# Altium schematic sheet P02_MPU1_JTAG.SchDoc(P02_MPU1_JTAG)
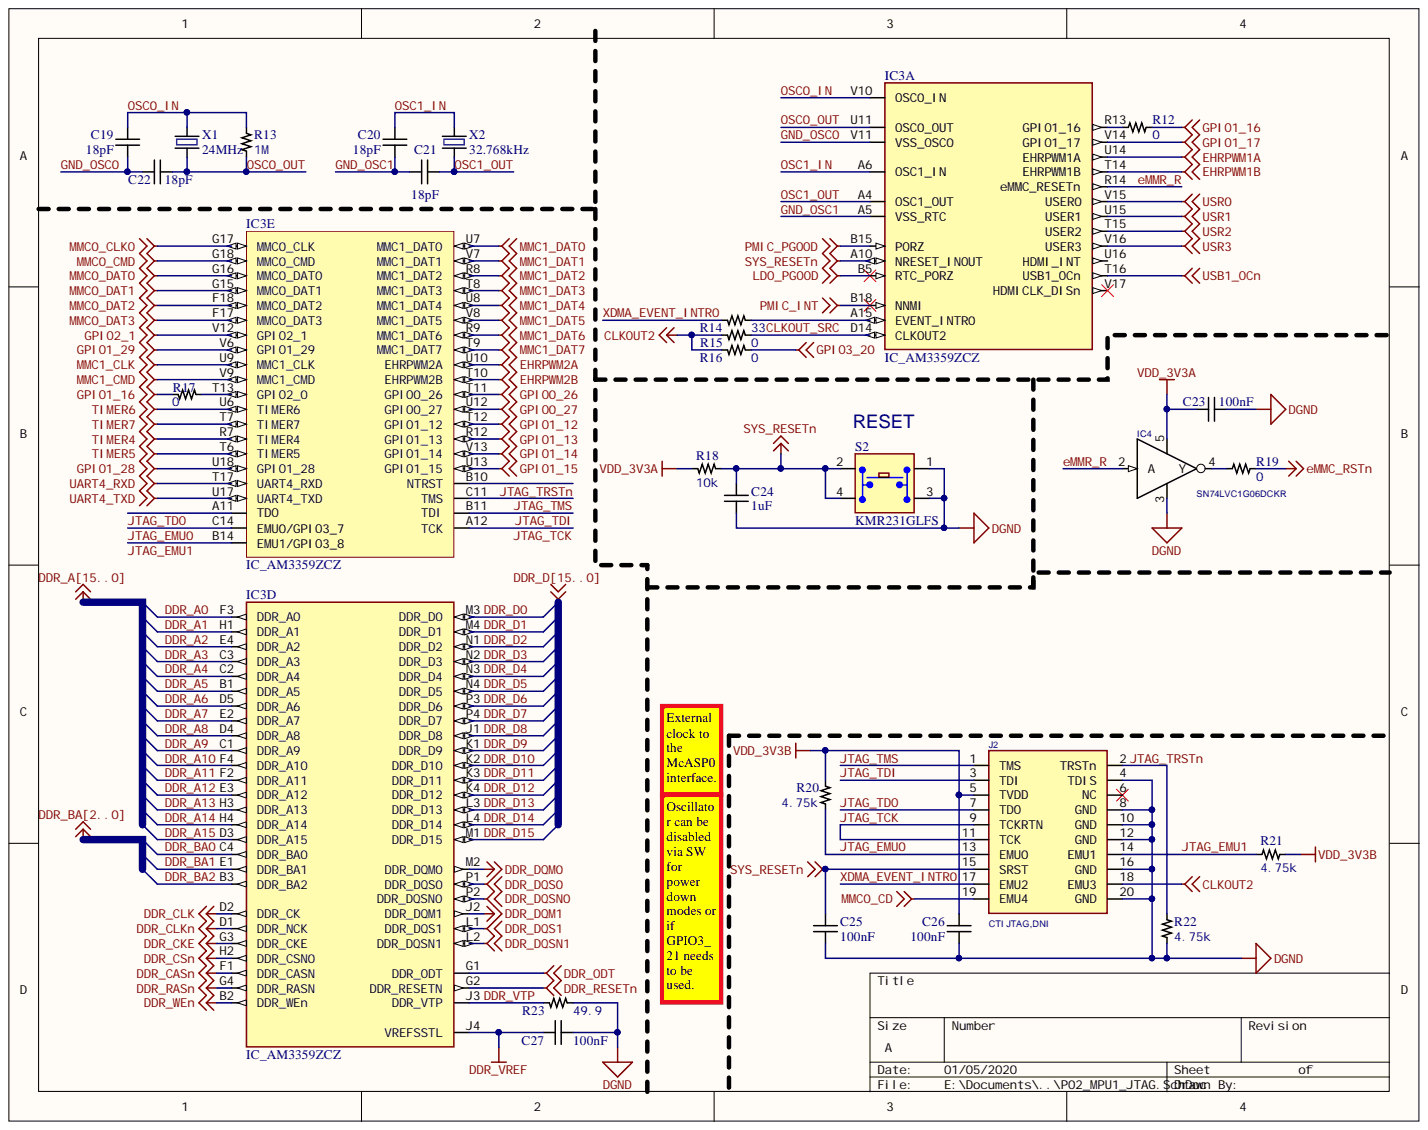
[diagram p0: P02_MPU1_JTAG.SchDoc]

## Parts
C19  Capacitance: 18pF  [CAP0402]  (hidden comment: CC0402JRNPO9BN180)
C20  Capacitance: 18pF  [CAP0402]  (hidden comment: CC0402JRNPO9BN180)
C21  Capacitance: 18pF  [CAP0402]  (hidden comment: CC0402JRNPO9BN180)
C22  Capacitance: 18pF  [CAP0402]  (hidden comment: CC0402JRNPO9BN180)
C23  Capacitance: 100nF  [CAP0402]  (hidden comment: C0402C104K4RACTU)
C24  Capacitance: 1uF  [CAP0402]  (hidden comment: CC0402KRX5R6BB105)
C25  Capacitance: 100nF  [CAP0402]  (hidden comment: C0402C104K4RACTU)
C26  Capacitance: 100nF  [CAP0402]  (hidden comment: C0402C104K4RACTU)
C27  Capacitance: 100nF  [CAP0402]  (hidden comment: C0402C104K4RACTU)
IC3  IC_AM3359ZCZ  [BGA-324]  (parts A,D,E)
IC4  SN74LVC1G06DCKR  [SC70_DCK]
J2  CTI JTAG,DNI  [HEADER10X2_SMD]
R12  RC0402JR-070RL  [RES0402]  (value not drawn)
R13  Resistance: 1M  [RES0402]  (hidden comment: RC0402FR-071ML)
R14  RC0402FR-0733RL  [RES0402]  (value not drawn)
R15  RC0402JR-070RL  [RES0402]  (value not drawn)
R16  RC0402JR-070RL  [RES0402]  (value not drawn)
R17  RC0402JR-070RL  [RES0402]  (value not drawn)
R18  Resistance: 10k  [RES0402]  (hidden comment: RC0402FR-0710KL)
R19  RC0402JR-070RL  [RES0402]  (value not drawn)
R20  Resistance: 4.75k  [RES0402]  (hidden comment: RC0402FR-074K75L)
R21  Resistance: 4.75k  [RES0402]  (hidden comment: RC0402FR-074K75L)
R22  Resistance: 4.75k  [RES0402]  (hidden comment: RC0402FR-074K75L)
R23  Resistance: 49.9  [RES0402]  (hidden comment: RC0402FR-0749R9)
S2  KMR231GLFS  [SW4SMD_63X161LS]
X1  24MHz  [XTAL150SMD_125X196]
X2  32.768kHz  [SOJ-4]

## Nets
CLKOUT2: J2.18(EMU3) R15.2 R16.2
DDR_CASn: IC3.F1(DDR_CASN)
DDR_CKE: IC3.G3(DDR_CKE)
DDR_CLK: IC3.D2(DDR_CK)
DDR_CLKn: IC3.D1(DDR_NCK)
DDR_CSn: IC3.H2(DDR_CSN0)
DDR_DQM0: IC3.M2(DDR_DQM0)
DDR_DQM1: IC3.J2(DDR_DQM1)
DDR_DQS0: IC3.P1(DDR_DQS0)
DDR_DQS1: IC3.L1(DDR_DQS1)
DDR_DQSN0: IC3.P2(DDR_DQSN0)
DDR_DQSN1: IC3.L2(DDR_DQSN1)
DDR_ODT: IC3.G1(DDR_ODT)
DDR_RASn: IC3.G4(DDR_RASN)
DDR_RESETn: IC3.G2(DDR_RESETN)
DDR_VREF: C27.1 IC3.J4(VREFSSTL)
DDR_WEn: IC3.B2(DDR_WEn)
DGND: C23.1 C24.1 C25.2 C26.2 C27.2 IC4.3 J2.4(TDIS) J2.8(GND) J2.10(GND) J2.12(GND) J2.16(GND) J2.20(GND) R22.1 R23.1 S2.1 S2.3
EHRPWM1A: IC3.U14(EHRPWM1A)
EHRPWM1B: IC3.T14(EHRPWM1B)
EHRPWM2A: IC3.U10(EHRPWM2A)
EHRPWM2B: IC3.T10(EHRPWM2B)
eMMC_RSTn: R19.2
GPIO0_26: IC3.T11(GPIO0_26)
GPIO0_27: IC3.U12(GPIO0_27)
GPIO1_12: IC3.T12(GPIO1_12)
GPIO1_13: IC3.R12(GPIO1_13)
GPIO1_14: IC3.V13(GPIO1_14)
GPIO1_15: IC3.U13(GPIO1_15)
GPIO1_16: R12.2 R17.2
GPIO1_17: IC3.V14(GPIO1_17)
GPIO1_28: IC3.U18(GPIO1_28)
GPIO1_29: IC3.V6(GPIO1_29)
GPIO2_1: IC3.V12(GPIO2_1)
GPIO3_20: R16.1
LDO_PGOOD: IC3.B5(RTC_PORZ)
MMC0_CD: J2.19(EMU4)
MMC0_CLKO: IC3.G17(MMC0_CLK)
MMC0_CMD: IC3.G18(MMC0_CMD)
MMC0_DAT0: IC3.G16(MMC0_DAT0)
MMC0_DAT1: IC3.G15(MMC0_DAT1)
MMC0_DAT2: IC3.F18(MMC0_DAT2)
MMC0_DAT3: IC3.F17(MMC0_DAT3)
MMC1_CLK: IC3.U9(MMC1_CLK)
MMC1_CMD: IC3.V9(MMC1_CMD)
MMC1_DAT0: IC3.U7(MMC1_DAT0)
MMC1_DAT1: IC3.V7(MMC1_DAT1)
MMC1_DAT2: IC3.R8(MMC1_DAT2)
MMC1_DAT3: IC3.T8(MMC1_DAT3)
MMC1_DAT4: IC3.U8(MMC1_DAT4)
MMC1_DAT5: IC3.V8(MMC1_DAT5)
MMC1_DAT6: IC3.R9(MMC1_DAT6)
MMC1_DAT7: IC3.T9(MMC1_DAT7)
PMIC_INT: IC3.B18(NNMI)
PMIC_PGOOD: IC3.B15(PORZ)
SYS_RESETn: C24.2 C25.1 IC3.A10(NRESET_INOUT) J2.15(SRST) R18.2 S2.2 S2.4
TIMER4: IC3.R7(TIMER4)
TIMER5: IC3.T6(TIMER5)
TIMER6: IC3.U6(TIMER6)
TIMER7: IC3.T7(TIMER7)
UART4_RXD: IC3.T17(UART4_RXD)
UART4_TXD: IC3.U17(UART4_TXD)
USB1_OCn: IC3.T16(USB1_OCn)
USR0: IC3.V15(USER0)
USR1: IC3.U15(USER1)
USR2: IC3.T15(USER2)
USR3: IC3.V16(USER3)
VDD_3V3A: C23.2 IC4.5 R18.1
VDD_3V3B: C26.1 J2.5(TVDD) R20.2 R21.1
CLKOUT_SRC: IC3.D14(CLKOUT2) R15.1
DDR_A0: IC3.F3(DDR_A0)  [also labelled DDR_A]
DDR_A1: IC3.H1(DDR_A1)  [also labelled DDR_A]
DDR_A2: IC3.E4(DDR_A2)  [also labelled DDR_A]
DDR_A3: IC3.C3(DDR_A3)  [also labelled DDR_A]
DDR_A4: IC3.C2(DDR_A4)  [also labelled DDR_A]
DDR_A5: IC3.B1(DDR_A5)  [also labelled DDR_A]
DDR_A6: IC3.D5(DDR_A6)  [also labelled DDR_A]
DDR_A7: IC3.E2(DDR_A7)  [also labelled DDR_A]
DDR_A8: IC3.D4(DDR_A8)  [also labelled DDR_A]
DDR_A9: IC3.C1(DDR_A9)  [also labelled DDR_A]
DDR_A10: IC3.F4(DDR_A10)  [also labelled DDR_A]
DDR_A11: IC3.F2(DDR_A11)  [also labelled DDR_A]
DDR_A12: IC3.E3(DDR_A12)  [also labelled DDR_A]
DDR_A13: IC3.H3(DDR_A13)  [also labelled DDR_A]
DDR_A14: IC3.H4(DDR_A14)  [also labelled DDR_A]
DDR_A15: IC3.D3(DDR_A15)  [also labelled DDR_A]
DDR_BA0: IC3.C4(DDR_BA0)  [also labelled DDR_BA]
DDR_BA1: IC3.E1(DDR_BA1)  [also labelled DDR_BA]
DDR_BA2: IC3.B3(DDR_BA2)  [also labelled DDR_BA]
DDR_D0: IC3.M3(DDR_D0)  [also labelled DDR_D]
DDR_D1: IC3.M4(DDR_D1)  [also labelled DDR_D]
DDR_D2: IC3.N1(DDR_D2)  [also labelled DDR_D]
DDR_D3: IC3.N2(DDR_D3)  [also labelled DDR_D]
DDR_D4: IC3.N3(DDR_D4)  [also labelled DDR_D]
DDR_D5: IC3.N4(DDR_D5)  [also labelled DDR_D]
DDR_D6: IC3.P3(DDR_D6)  [also labelled DDR_D]
DDR_D7: IC3.P4(DDR_D7)  [also labelled DDR_D]
DDR_D8: IC3.J1(DDR_D8)  [also labelled DDR_D]
DDR_D9: IC3.K1(DDR_D9)  [also labelled DDR_D]
DDR_D10: IC3.K2(DDR_D10)  [also labelled DDR_D]
DDR_D11: IC3.K3(DDR_D11)  [also labelled DDR_D]
DDR_D12: IC3.K4(DDR_D12)  [also labelled DDR_D]
DDR_D13: IC3.L3(DDR_D13)  [also labelled DDR_D]
DDR_D14: IC3.L4(DDR_D14)  [also labelled DDR_D]
DDR_D15: IC3.M1(DDR_D15)  [also labelled DDR_D]
DDR_VTP: IC3.J3(DDR_VTP) R23.2
eMMR_R: IC3.R14(eMMC_RESETn) IC4.2(A)
GND_OSC0: C19.2 C22.1 IC3.V11(VSS_OSC0)
GND_OSC1: C20.1 C21.2 IC3.A5(VSS_RTC)
JTAG_EMU0: IC3.C14(EMU0/GPIO3_7) J2.13(EMU0) R20.1
JTAG_EMU1: IC3.B14(EMU1/GPIO3_8) J2.14(EMU1) R21.2
JTAG_TCK: IC3.A12(TCK) J2.9(TCKRTN) J2.11(TCK)
JTAG_TDI: IC3.B11(TDI) J2.3(TDI)
JTAG_TDO: IC3.A11(TDO) J2.7(TDO)
JTAG_TMS: IC3.C11(TMS) J2.1(TMS)
JTAG_TRSTn: IC3.B10(NTRST) J2.2(TRSTn) R22.2
OSC0_IN: C19.1 IC3.V10(OSC0_IN) R13.2 X1.2
OSC0_OUT: C22.2 IC3.U11(OSC0_OUT) R13.1 X1.1
OSC1_IN: C20.2 IC3.A6(OSC1_IN) X2.1
OSC1_OUT: C21.1 IC3.A4(OSC1_OUT) X2.4
XDMA_EVENT_INTR0: J2.17(EMU2) R14.1
NetIC3_A15: IC3.A15(EVENT_INTR0) R14.2
NetIC3_R13: IC3.R13(GPIO1_16) R12.1
NetIC3_T13: IC3.T13(GPIO2_0) R17.1
NetIC4_4: IC4.4(Y) R19.1

Not connected: IC3 U16(HDMI_INT) V17(HDMICLK_DISn); J2 6(NC)

## Library data of the parts
C19-C22 = CAP_18pF: MLCC 18pF 0402 SMD; Manufacturer: Yageo; Voltage Rating (DC): 50V; Tolerance: 5%; Temperature Coefficient: 30ppm/°C; Dielectric: C0G; Width: 0.02inch; Weight: 2.3E-05oz; Voltage Rating: 50V; Voltage: 50V; Thickness: 0.022inch; Termination: SMD/SMT; Supplier 1: Digi-Key; Stock 1: 740828; RoHS: Yes; REACH SVHC: No SVHC; Radiation Hardening: No; Pricing 1: 1=0.10000, 10=0.02300, 100=0.01030, 500=0.00736, 1000=0.00578, 2500=0.00525, 5000=0.00483 (USD); Packaging: Tape and Reel; Package Quantity: 1; Number of Pins: 2; Mount: Surface Mount; Min Operating Temperature: -55°C; Max Operating Temperature: 125°C; Manufacturer Part Number: CC0402JRNPO9BN180; Length: 1mm; Lead Free: Lead Free; Height: 0.5mm; Depth: 0.5mm; Composition: Ceramic; ComponentLink7URL: https://datasheet.ciiva.com/11587/upy-gp-np0-16v-to-50v-8-11587087.pdf?src-supplier=Avnet; ComponentLink7Description: https://datasheet.ciiva.com/11587/upy-gp-np0-16v-to-50v-8-11587087.pdf?src-supplier=Avnet; ComponentLink6URL: https://datasheet.ciiva.com/13208/upy-gp-np0-16v-to-50v-8-13208430.pdf?src-supplier=Mouser; ComponentLink6Description: https://datasheet.ciiva.com/13208/upy-gp-np0-16v-to-50v-8-13208430.pdf?src-supplier=Mouser; ComponentLink5URL: https://datasheet.ciiva.com/28450/1443161-28450232.pdf?src-supplier=Element14; ComponentLink5Description: https://datasheet.ciiva.com/28450/1443161-28450232.pdf?src-supplier=Element14; ComponentLink4URL: https://datasheet.ciiva.com/176/mlccorderingcode-176359.pdf?src-supplier=Digi-Key; ComponentLink4Description: https://datasheet.ciiva.com/176/mlccorderingcode-176359.pdf?src-supplier=Digi-Key; ComponentLink3URL: https://datasheet.ciiva.com/176/mlccorderingcode-176358.pdf?src-supplier=Digi-Key; ComponentLink3Description: https://datasheet.ciiva.com/176/mlccorderingcode-176358.pdf?src-supplier=Digi-Key; ComponentLink2URL: https://datasheet.ciiva.com/176/mlccorderingcode-176357.pdf?src-supplier=Digi-Key; ComponentLink2Description: https://datasheet.ciiva.com/176/mlccorderingcode-176357.pdf?src-supplier=Digi-Key; ComponentLink1URL: https://datasheet.ciiva.com/11788/0900766b80d84fbf-11788369.pdf?src-supplier=RSComponents; ComponentLink1Description: https://datasheet.ciiva.com/11788/0900766b80d84fbf-11788369.pdf?src-supplier=RSComponents; Component Kind: Standard; Case Code (Metric): 1005; Case Code (Imperial): 0402; Case/Package: 0402; Library Name: Orient.SchLib
C23 C25-C27 = CAP_100nF: MLCC 100nF 0402 SMD; Manufacturer: KEMET; Voltage Rating (DC): 16V; Tolerance: 10%; Dissipation Factor: 3.5%; Dielectric: X7R; Width: 0.5mm; Voltage Rating: 16V; Termination: SMD/SMT; Supplier Part Number 1: 399-3521-1-ND; Supplier 1: Digi-Key; Stock 1: 6512243; RoHS: Yes; Radiation Hardening: No; Pricing 1: 1=0.10000, 10=0.04200, 100=0.01860, 500=0.01328, 1000=0.01044, 2500=0.00949, 5000=0.00873 (USD); Packaging: Tape and Reel; Package Quantity: 10000; Mount: Surface Mount; Min Operating Temperature: -55°C; Max Operating Temperature: 125°C; Manufacturer Part Number: C0402C104K4RACTU; Length: 1mm; Height: 0.5mm; Depth: 0.5mm; Contact Plating: Tin,Matte; ComponentLink2URL: https://datasheet.ciiva.com/18192/getdatasheetpartid-385384-18192412.pdf?src-supplier=Verical; ComponentLink2Description: Datasheet; Component Kind: Standard; Case Code (Metric): 1005; Case Code (Imperial): 0402; Case/Package: 0402; Library Name: Orient.SchLib
C24 = CAP_1uF: MLCC 1uF 0402 SMD; Manufacturer: Yageo; Voltage Rating (DC): 10V; Tolerance: 10%; Dissipation Factor: 15%; Dielectric: X5R; Width: 0.02inch; Voltage Rating: 10V; Thickness: 0.022inch; Termination: SMD/SMT; Supplier 1: Digi-Key; Stock 1: 6411796; RoHS: Yes; REACH SVHC: No SVHC; Radiation Hardening: No; Pricing 1: 1=0.10000, 10=0.06300, 100=0.02790, 500=0.01996, 1000=0.01568, 2500=0.01425, 5000=0.01311 (USD); Packaging: Tape and Reel; Package Quantity: 1; Number of Pins: 2; Mount: Surface Mount; Min Operating Temperature: -55°C; Max Operating Temperature: 85°C; Manufacturer Part Number: CC0402KRX5R6BB105; Length: 1mm; Lead Free: Lead Free; Height: 0.5mm; Halogen Free: Halogen Free; Depth: 0.5mm; Composition: Ceramic; ComponentLink3URL: https://datasheet.ciiva.com/176/mlccorderingcode-176705.pdf?src-supplier=Digi-Key; ComponentLink3Description: https://datasheet.ciiva.com/176/mlccorderingcode-176705.pdf?src-supplier=Digi-Key; ComponentLink2URL: https://datasheet.ciiva.com/176/mlccorderingcode-176706.pdf?src-supplier=Digi-Key; ComponentLink2Description: https://datasheet.ciiva.com/176/mlccorderingcode-176706.pdf?src-supplier=Digi-Key; ComponentLink1URL: https://datasheet.ciiva.com/176/upy-gphc-x5r-4v-to-50v-12-176704.pdf?src-supplier=Digi-Key; ComponentLink1Description: https://datasheet.ciiva.com/176/upy-gphc-x5r-4v-to-50v-12-176704.pdf?src-supplier=Digi-Key; Component Kind: Standard; Case Code (Metric): 1005; Case Code (Imperial): 0402; Case/Package: 0402; Library Name: Orient.SchLib
IC3 = IC_AM335X_ZCE_1: SubArctic Cortex A8 Processor 18x18; Manufacturer Part Number: XAM3359ZCZ; Manufacturer: Texas Instruments; Voltage: 1.06 V ~ 1.15 V; Processor Type: ARM Microprocessor; Supplier Part Number 1: 296-35073-ND; Supplier Device Package: 324-NFBGA(15x15); Supplier 1: Digi-Key; Stock 1: 16; Speed: -; RoHS: RoHS Compliant; Pricing 1: 1=33.5, 10=30.988, 100=26.465, 250=24.28752, 500=23.115, 1000=21.775, 2500=21.105, 5000=20.6025, 10000=20.1 (USD); Packaging: Tray; Package / Case: 324-LFBGA; Mounting Type: Surface Mount; Features: -; ComponentLink1URL: http://www.ti.com/lit/ds/symlink/am3354.pdf; ComponentLink1Description: http://www.ti.com/lit/ds/symlink/am3354.pdf; Component Kind: Standard; Category: Integrated Circuits (ICs); Library Name: Orient.SchLib
IC4 = IC_Inverter: IC INVERTER SINGLE 1INPUT SC705; Manufacturer Part Number: SN74LVC1G06DCKTG4; Manufacturer: Texas Instruments; Voltage - Supply: 1.65 V ~ 5.5 V; Turn-On Delay Time: 3ns; Quiescent Current: 10uA; Propagation Delay: 6.5ns; Polarity: Inverting; Output Current: 32mA; Number of Outputs: 1; Min Supply Voltage: 1.65V; Max Supply Voltage: 5.5V; Max Propagation Delay @ V, Max CL: 3ns @ 5V, 50pF; Logic Type: Inverter, Buffer; Logic Level - Low: 0.7 V ~ 0.8 V; Logic Level - High: 1.7 V ~ 2 V; Logic Function: Buffer,Inverter; Current - Quiescent (Max): 10µA; Current - Output High, Low: -, 32mA; Width: 1.25mm; Supplier Part Number 1: SN74LVC1G06DCKTG4-ND; Supplier Device Package: SC-70-5; Supplier 2: Digi-Key; Supplier 1: Digi-Key; Stock 2: 23745; Stock 1: 0; RoHS: RoHS Compliant; REACH SVHC: No SVHC; Radiation Hardening: No; Pricing 2: 1=0.33000, 10=0.24700, 100=0.14000, 500=0.09270, 1000=0.07107 (USD); Pricing 1: 1250=0.32 (USD); Packaging: Tape & Reel (TR); Package Quantity: 3000; Package / Case: 6-TSSOP (5 Lead), SC-88A, SOT-353; Operating Temperature: -40°C ~ 85°C; Number of Pins: 5; Number of Output Lines: 1; Number of Inputs: 1; Number of Input Lines: 1; Number of Gates: 1; Number of Elements: 1; Number of Circuits: 1; Number of Channels: 1; Number of Bits: 1; Mounting Type: Surface Mount; Mount: Surface Mount; Min Operating Temperature: -40°C; Max Operating Temperature: 125°C; Low Level Output Current: 32mA; Length: 2mm; Lead Free: Lead Free; High Level Output Current: 32mA; Height: 0.9mm; Features: Open Drain; Contact Plating: Gold; ComponentLink10URL: https://datasheet.ciiva.com/26220/sn74lvc1g06-26220911.pdf?src-supplier=Avnet+Europe; ComponentLink10Description: https://datasheet.ciiva.com/26220/sn74lvc1g06-26220911.pdf?src-supplier=Avnet+Europe; ComponentLink9URL: https://datasheet.ciiva.com/46442/1857056-46442057.pdf?src-supplier=Element14; ComponentLink9Description: https://datasheet.ciiva.com/46442/1857056-46442057.pdf?src-supplier=Element14; ComponentLink8URL: https://datasheet.ciiva.com/7783/1633749-7783590.pdf?src-supplier=Element14; ComponentLink8Description: https://datasheet.ciiva.com/7783/1633749-7783590.pdf?src-supplier=Element14; ComponentLink7URL: https://datasheet.ciiva.com/16531/sn74lvc1g06-16531989.pdf?src-supplier=Avnet+Asia; ComponentLink7Description: https://datasheet.ciiva.com/16531/sn74lvc1g06-16531989.pdf?src-supplier=Avnet+Asia; ComponentLink6URL: https://datasheet.ciiva.com/1864/sn74lvc1g06-1864403.pdf?src-supplier=Digi-Key; ComponentLink6Description: https://datasheet.ciiva.com/1864/sn74lvc1g06-1864403.pdf?src-supplier=Digi-Key; ComponentLink5URL: https://datasheet.ciiva.com/1864/sn74lvc1g06-1864402.pdf?src-supplier=Digi-Key; ComponentLink5Description: https://datasheet.ciiva.com/1864/sn74lvc1g06-1864402.pdf?src-supplier=Digi-Key; ComponentLink4URL: https://datasheet.ciiva.com/1864/sn74lvc1g06-1864401.pdf?src-supplier=Digi-Key; ComponentLink4Description: https://datasheet.ciiva.com/1864/sn74lvc1g06-1864401.pdf?src-supplier=Digi-Key; ComponentLink3URL: https://datasheet.ciiva.com/34301/1043820-34301914.pdf?src-supplier=Jameco; ComponentLink3Description: https://datasheet.ciiva.com/34301/1043820-34301914.pdf?src-supplier=Jameco; ComponentLink2URL: https://datasheet.ciiva.com/15094/getdatasheetpartid-76668-15094547.pdf?src-supplier=Verical; ComponentLink2Description: https://datasheet.ciiva.com/15094/getdatasheetpartid-76668-15094547.pdf?src-supplier=Verical; ComponentLink1URL: http://www.ti.com/lit/ds/symlink/sn74lvc1g06.pdf; ComponentLink1Description: http://www.ti.com/lit/ds/symlink/sn74lvc1g06.pdf; Component Kind: Standard; Category: Integrated Circuits (ICs); Case/Package: SC; Library Name: Orient.SchLib
J2 = HDR_10x2_CJTAG: Dual Line Header 10x2pin Tin; Manufacturer Part Number: FTR-110-03-G-D-06; Manufacturer: Samtec Inc; Row Spacing: 0.100" (2.54mm); Pitch: 0.050" (1.27mm); Number of Positions Loaded: 19; Number of Positions: 20; Connector Type: Unshrouded; Termination: Solder; Supplier Part Number 1: FTR-110-03-G-D-06-ND; Supplier 1: Digi-Key; Stock 1: 0; RoHS: RoHS Compliant; Pricing 1: 1=4.47 (USD); Packaging: Tube; Number of Rows: 2; Mounting Type: Surface Mount; Molding Height Above Board: 0.100" (2.54mm); Height Stacking (Mating): -; Features: -; Contact Mating Length: 0.125" (3.18mm); Contact Finish Thickness: 10µin (0.25µm); Contact Finish: Gold; ComponentLink1URL: http://www.samtec.com/documents/webfiles/cpdf/FTR-1XX-XX-XX-D-X-XX-MKT.pdf; ComponentLink1Description: http://www.samtec.com/documents/webfiles/cpdf/FTR-1XX-XX-XX-D-X-XX-MKT.pdf; Component Kind: Standard; Color: Black; Category: Connectors, Interconnects; Library Name: Orient.SchLib
R12 R15-R17 R19 = RES_0: Resistor 0Ohm 0402 SMD; Manufacturer: Yageo; Voltage Rating: 50V; Tolerance: 5%; Temperature Coefficient: 200ppm/°C; Resistance: 0; Power Rating: 62.5mW; Max Power Dissipation: 63mW; Width: 0.02inch; Termination: SMD/SMT; Supplier Part Number 1: 311-0.0JRCT-ND; Supplier 1: Digi-Key; Stock 1: 68160806; RoHS: Yes; REACH SVHC: Unknown; Radiation Hardening: No; Pricing 1: 1=0.10000, 10=0.01300, 25=0.00920, 50=0.00700, 100=0.00510, 250=0.00392, 500=0.00312, 1000=0.00230, 5000=0.00165 (USD); Packaging: Tape and Reel; Package Quantity: 1; Number of Terminations: 2; Min Operating Temperature: -55°C; Military Standard: Not; Max Operating Temperature: 155°C; Manufacturer Part Number: RC0402JR-070RL; Length: 1mm; Lead Free: Lead Free; Height: 0.016inch; Features: Moisture Resistant; Depth: 0.5mm; Contact Plating: Tin,Matte; Composition: Thick Film; ComponentLink2URL: https://www.yageo.com/upload/media/product/productsearch/datasheet/rchip/PYu-RC_Group_51_RoHS_L_10.pdf; ComponentLink2Description: Datasheet; ComponentLink1URL: https://www.digikey.com/product-detail/en/yageo/RC0402JR-070RL/311-0.0JRCT-ND/729353; ComponentLink1Description: Digikey; Component Kind: Standard; Case Code (Metric): 1005; Case Code (Imperial): 0402; Case/Package: 0402; Library Name: Orient.SchLib
R13 = RES_1M: Resistor 1MOhm 0402 SMD; Manufacturer: Yageo; Voltage Rating: 50V; Tolerance: 1%; Temperature Coefficient: 100ppm/°C; Power Rating: 63mW; Termination: SMD/SMT; Supplier 1: Digi-Key; Stock 1: 11890826; RoHS: Yes; REACH SVHC: Unknown; Pricing 1: 1=0.10000, 10=0.01500, 100=0.00600, 1000=0.00268, 2500=0.00232, 5000=0.00192 (USD); Packaging: Tape and Reel; Min Operating Temperature: -55°C; Military Standard: Not; Max Operating Temperature: 155°C; Manufacturer Part Number: RC0402FR-071ML; Length: 1mm; Lead Free: Lead Free; Height: 0.32mm; Depth: 0.5mm; Composition: Thick Film; ComponentLink5URL: https://datasheet.ciiva.com/7849/1018594-7849883.pdf?src-supplier=Element14; ComponentLink5Description: https://datasheet.ciiva.com/7849/1018594-7849883.pdf?src-supplier=Element14; ComponentLink4URL: https://datasheet.ciiva.com/7849/1018594-7849882.pdf?src-supplier=Element14; ComponentLink4Description: https://datasheet.ciiva.com/7849/1018594-7849882.pdf?src-supplier=Element14; ComponentLink3URL: https://datasheet.ciiva.com/16741/pyu-rc0402-51-rohs-l-6-r-16741081.pdf?src-supplier=Future+Electronics; ComponentLink3Description: https://datasheet.ciiva.com/16741/pyu-rc0402-51-rohs-l-6-r-16741081.pdf?src-supplier=Future+Electronics; ComponentLink2URL: https://datasheet.ciiva.com/46394/1018594-46394951.pdf?src-supplier=Element14; ComponentLink2Description: https://datasheet.ciiva.com/46394/1018594-46394951.pdf?src-supplier=Element14; ComponentLink1URL: https://datasheet.ciiva.com/11808/0900766b80c66297-11808125.pdf?src-supplier=RSComponents; ComponentLink1Description: https://datasheet.ciiva.com/11808/0900766b80c66297-11808125.pdf?src-supplier=RSComponents; Component Kind: Standard; Case Code (Metric): 1005; Case Code (Imperial): 0402; Case/Package: 0402; Library Name: Orient.SchLib
R14 = RES_33: Resistor 33Ohm 0402 SMD; Manufacturer: Yageo; Voltage Rating (DC): 50V; Tolerance: 1%; Temperature Coefficient: 100ppm/°C; Resistance: 33; Power Rating: 62.5mW; Width: 0.5mm; Voltage Rating: 50V; Termination: SMD/SMT; Supplier 1: Digi-Key; Stock 1: 5631148; RoHS: Yes; REACH SVHC: Unknown; Pricing 1: 1=0.10000, 10=0.01600, 100=0.00640, 1000=0.00289, 2500=0.00251, 5000=0.00207 (USD); Packaging: Tape and Reel; Package Quantity: 10000; Number of Pins: 2; Min Operating Temperature: -55°C; Military Standard: Not; Max Operating Temperature: 155°C; Manufacturer Part Number: RC0402FR-0733RL; Length: 1mm; Lead Free: Lead Free; Height: 0.35mm; Depth: 0.5mm; Composition: Thick Film; ComponentLink6URL: https://datasheet.ciiva.com/17070/pyu-rc0402-51-rohs-l-6-r-17070335.pdf?src-supplier=Future+Electronics; ComponentLink6Description: https://datasheet.ciiva.com/17070/pyu-rc0402-51-rohs-l-6-r-17070335.pdf?src-supplier=Future+Electronics; ComponentLink5URL: https://datasheet.ciiva.com/7849/1018594-7849967.pdf?src-supplier=Element14; ComponentLink5Description: https://datasheet.ciiva.com/7849/1018594-7849967.pdf?src-supplier=Element14; ComponentLink4URL: https://datasheet.ciiva.com/7849/1018594-7849966.pdf?src-supplier=Element14; ComponentLink4Description: https://datasheet.ciiva.com/7849/1018594-7849966.pdf?src-supplier=Element14; ComponentLink3URL: https://datasheet.ciiva.com/24070/pyu-rc0402-51-rohs-l-6-r-8721-24070077.pdf?src-supplier=Mouser; ComponentLink3Description: https://datasheet.ciiva.com/24070/pyu-rc0402-51-rohs-l-6-r-8721-24070077.pdf?src-supplier=Mouser; ComponentLink2URL: https://datasheet.ciiva.com/15189/getdatasheetpartid-329253-15189431.pdf?src-supplier=Verical; ComponentLink2Description: https://datasheet.ciiva.com/15189/getdatasheetpartid-329253-15189431.pdf?src-supplier=Verical; ComponentLink1URL: https://datasheet.ciiva.com/11819/0900766b80c66297-11819487.pdf?src-supplier=RSComponents; ComponentLink1Description: https://datasheet.ciiva.com/11819/0900766b80c66297-11819487.pdf?src-supplier=RSComponents; Component Kind: Standard; Case Code (Metric): 1005; Case Code (Imperial): 0402; Case/Package: 0402; Library Name: Orient.SchLib
R18 = RES_10k: Resistor 10kOhm 0402 SMD; Manufacturer: Yageo; Working Voltage: 50V; Tolerance: 1%; Temperature Coefficient: 100ppm/°C; Power Rating: 62.5mW; Width: 0.5mm; Voltage Rating (DC): 50V; Voltage Rating: 50V; Termination: SMD/SMT; Supplier 1: Digi-Key; Stock 1: 72891652; RoHS: Yes; REACH SVHC: No SVHC; Pricing 1: 1=0.10000, 10=0.01500, 100=0.00600, 1000=0.00270, 2500=0.00235, 5000=0.00194 (USD); Packaging: Tape and Reel; Number of Terminations: 2; Number of Pins: 2; Min Operating Temperature: -55°C; Military Standard: Not; Max Operating Temperature: 155°C; Manufacturer Part Number: RC0402FR-0710KL; Length: 1mm; Lead Pitch: 0.2mm; Lead Free: Lead Free; Height: 0.32mm; Depth: 0.5mm; Composition: Thick Film; ComponentLink7URL: https://datasheet.ciiva.com/12667/pyu-rc0402-51-rohs-l-6-r-12667067.pdf?src-supplier=Mouser; ComponentLink7Description: https://datasheet.ciiva.com/12667/pyu-rc0402-51-rohs-l-6-r-12667067.pdf?src-supplier=Mouser; ComponentLink6URL: https://datasheet.ciiva.com/22747/1018594-22747512.pdf?src-supplier=Element14; ComponentLink6Description: https://datasheet.ciiva.com/22747/1018594-22747512.pdf?src-supplier=Element14; ComponentLink5URL: https://datasheet.ciiva.com/7849/1784430-7849815.pdf?src-supplier=Element14; ComponentLink5Description: https://datasheet.ciiva.com/7849/1784430-7849815.pdf?src-supplier=Element14; ComponentLink4URL: https://datasheet.ciiva.com/7849/1686128-7849814.pdf?src-supplier=Element14; ComponentLink4Description: https://datasheet.ciiva.com/7849/1686128-7849814.pdf?src-supplier=Element14; ComponentLink3URL: https://datasheet.ciiva.com/7849/1784430-7849813.pdf?src-supplier=Element14; ComponentLink3Description: https://datasheet.ciiva.com/7849/1784430-7849813.pdf?src-supplier=Element14; ComponentLink2URL: https://datasheet.ciiva.com/7983/1018594-7983486.pdf?src-supplier=Element14; ComponentLink2Description: https://datasheet.ciiva.com/7983/1018594-7983486.pdf?src-supplier=Element14; ComponentLink1URL: https://datasheet.ciiva.com/7660/38568-7660787.pdf?src-supplier=Element14; ComponentLink1Description: https://datasheet.ciiva.com/7660/38568-7660787.pdf?src-supplier=Element14; Component Kind: Standard; Case Code (Metric): 1005; Case Code (Imperial): 0402; Case/Package: 0402; Library Name: Orient.SchLib
R20-R22 = RES_4.75k: Resistor 4.75kOhm 0402 SMD; Manufacturer: Yageo; Working Voltage: 50V; Tolerance: 1%; Temperature Coefficient: 100ppm/°C; Power Rating: 62.5mW; Max Power Dissipation: 63mW; Width: 0.5mm; Voltage Rating (DC): 50V; Voltage Rating: 50V; Termination: SMD/SMT; Supplier 1: Digi-Key; Stock 1: 9368381; RoHS: Yes; REACH SVHC: No SVHC; Pricing 1: 1=0.10000, 10=0.01600, 100=0.00640, 1000=0.00289, 2500=0.00251, 5000=0.00207 (USD); Packaging: Tape and Reel; Package Quantity: 1; Number of Terminations: 2; Min Operating Temperature: -55°C; Military Standard: Not; Max Operating Temperature: 155°C; Manufacturer Part Number: RC0402FR-074K75L; Length: 1mm; Lead Free: Lead Free; Height: 0.016inch; Features: Moisture Resistant; Depth: 0.5mm; Composition: Thick Film; ComponentLink7URL: https://datasheet.ciiva.com/16744/pyu-rc0402-51-rohs-l-6-r-16744524.pdf?src-supplier=Future+Electronics; ComponentLink7Description: https://datasheet.ciiva.com/16744/pyu-rc0402-51-rohs-l-6-r-16744524.pdf?src-supplier=Future+Electronics; ComponentLink6URL: https://datasheet.ciiva.com/2553/pyu-r-int-thick-7-2553226.pdf?src-supplier=Digi-Key; ComponentLink6Description: https://datasheet.ciiva.com/2553/pyu-r-int-thick-7-2553226.pdf?src-supplier=Digi-Key; ComponentLink5URL: https://datasheet.ciiva.com/2553/pyu-r-int-thick-7-2553225.pdf?src-supplier=Digi-Key; ComponentLink5Description: https://datasheet.ciiva.com/2553/pyu-r-int-thick-7-2553225.pdf?src-supplier=Digi-Key; ComponentLink4URL: https://datasheet.ciiva.com/7983/1018594-7983544.pdf?src-supplier=Element14; ComponentLink4Description: https://datasheet.ciiva.com/7983/1018594-7983544.pdf?src-supplier=Element14; ComponentLink3URL: https://datasheet.ciiva.com/2553/pyu-r-int-thick-7-2553224.pdf?src-supplier=Digi-Key; ComponentLink3Description: https://datasheet.ciiva.com/2553/pyu-r-int-thick-7-2553224.pdf?src-supplier=Digi-Key; ComponentLink2URL: https://datasheet.ciiva.com/15189/getdatasheetpartid-326465-15189478.pdf?src-supplier=Verical; ComponentLink2Description: https://datasheet.ciiva.com/15189/getdatasheetpartid-326465-15189478.pdf?src-supplier=Verical; ComponentLink1URL: https://datasheet.ciiva.com/11808/0900766b80c66297-11808814.pdf?src-supplier=RSComponents; ComponentLink1Description: https://datasheet.ciiva.com/11808/0900766b80c66297-11808814.pdf?src-supplier=RSComponents; Component Kind: Standard; Case Code (Metric): 1005; Case Code (Imperial): 0402; Case/Package: 0402; Library Name: Orient.SchLib
R23 = RES_49.9: Resistor 49.9Ohm 0402 SMD; Manufacturer Part Number: RC0402FR-0749R9L; Manufacturer: Yageo; Working Voltage: 50V; Tolerance: 1%; Temperature Coefficient: 100ppm/°C; Power Rating: 62.5mW; Max Power Dissipation: 63mW; Width: 0.5mm; Voltage Rating (DC): 50V; Voltage Rating: 50V; Termination: SMD/SMT; Supplier 1: Digi-Key; Stock 1: 17398038; RoHS: Yes; REACH SVHC: No SVHC; Pricing 1: 1=0.10000, 10=0.01600, 100=0.00640, 1000=0.00289, 2500=0.00251, 5000=0.00207 (USD); Packaging: Tape and Reel; Package Quantity: 1; Number of Terminations: 2; Number of Pins: 2; Min Operating Temperature: -55°C; Military Standard: Not; Max Operating Temperature: 155°C; Length: 1mm; Lead Free: Lead Free; Height: 0.016inch; Features: Moisture Resistant; Depth: 0.5mm; Composition: Thick Film; ComponentLink7URL: https://datasheet.ciiva.com/2453/pyu-r-int-thick-7-2453357.pdf?src-supplier=Digi-Key; ComponentLink7Description: https://datasheet.ciiva.com/2453/pyu-r-int-thick-7-2453357.pdf?src-supplier=Digi-Key; ComponentLink6URL: https://datasheet.ciiva.com/2453/pyu-r-int-thick-7-2453356.pdf?src-supplier=Digi-Key; ComponentLink6Description: https://datasheet.ciiva.com/2453/pyu-r-int-thick-7-2453356.pdf?src-supplier=Digi-Key; ComponentLink5URL: https://datasheet.ciiva.com/2453/pyu-r-int-thick-7-2453355.pdf?src-supplier=Digi-Key; ComponentLink5Description: https://datasheet.ciiva.com/2453/pyu-r-int-thick-7-2453355.pdf?src-supplier=Digi-Key; ComponentLink4URL: https://datasheet.ciiva.com/22747/1018594-22747514.pdf?src-supplier=Element14; ComponentLink4Description: https://datasheet.ciiva.com/22747/1018594-22747514.pdf?src-supplier=Element14; ComponentLink3URL: https://datasheet.ciiva.com/16743/pyu-rc0402-51-rohs-l-6-r-16743942.pdf?src-supplier=Future+Electronics; ComponentLink3Description: https://datasheet.ciiva.com/16743/pyu-rc0402-51-rohs-l-6-r-16743942.pdf?src-supplier=Future+Electronics; ComponentLink2URL: https://datasheet.ciiva.com/7983/1018594-7983542.pdf?src-supplier=Element14; ComponentLink2Description: https://datasheet.ciiva.com/7983/1018594-7983542.pdf?src-supplier=Element14; ComponentLink1URL: https://datasheet.ciiva.com/11819/0900766b80c66297-11819508.pdf?src-supplier=RSComponents; ComponentLink1Description: https://datasheet.ciiva.com/11819/0900766b80c66297-11819508.pdf?src-supplier=RSComponents; Component Kind: Standard; Case Code (Metric): 1005; Case Code (Imperial): 0402; Case/Package: 0402; Library Name: Orient.SchLib
S2 = KMR2: Microminiature Tact Switch for SMT; Manufacturer: C&K Components; Switch Travel: 0.25mm; Switch Function: Off-Mom; Outline: 4.60mm x 2.80mm; Operating Force: 300gf; Mechanical Life: 150,000 Cycles; Illumination: Non-Illuminated; Contact Rating @ Voltage: 0.05A @ 32VDC; Circuit: SPST-NO; Actuator Type: Standard; Actuator Orientation: Top Actuated; Actuator Height off PCB, from Pins: 1.90mm; Termination Style: Gull Wing; Supplier Part Number 1: 401-1429-6-ND; Supplier 1: Digi-Key; Stock 1: 32289; RoHS: RoHS Compliant; Pricing 1: 1=0.44, 10=0.416, 25=0.3744, 50=0.3326, 100=0.3188, 250=0.29108, 500=0.2772, 1000=0.22869 (USD); Packaging: Digi-Reel®; Operating Temperature: -40°C ~ 85°C; Mounting Type: Surface Mount; Manufacturer Part Number: KMR231GLFS; Library Name: Orient.SchLib; Ingress Protection: -; Illumination Voltage (Nominal): -; Illumination Type, Color: -; Features: Grounding Pin; ComponentLink1URL: http://www.ck-components.com/index.php?module=media&action=Display&cmpref=14414&lang=en&width=&height=&format=&alt=; ComponentLink1Description: http://www.ck-components.com/index.php?module=media&action=Display&cmpref=14414&lang=en&width=&height=&format=&alt=; Component Kind: Standard; Category: Switches; Actuator Material: -
X1 = CRYS_24MHz_SCC: CRYSTAL 24.000MHZ 18PF SMD; Manufacturer Part Number: 7A-24.000MAAJ-T; Manufacturer: TXC CORPORATION; Operating Mode: Fundamental; Frequency Tolerance: ±30ppm; ESR (Equivalent Series Resistance): 50 Ohm; Type: MHz Crystal; Supplier Part Number 1: 887-1090-6-ND; Supplier 1: Digi-Key; Stock 1: 2524; Size / Dimension: 0.197" L x 0.126" W (5.00mm x 3.20mm); RoHS: RoHS Compliant; Ratings: -; Pricing 1: 1=0.79, 10=0.658, 50=0.59, 100=0.525, 500=0.5 (USD); Packaging: Digi-Reel®; Package / Case: 2-SMD; Operating Temperature: -20°C ~ 70°C; Mounting Type: Surface Mount; Load Capacitance: 18pF; Height: 0.053" (1.35mm); Frequency Stability: ±30ppm; Frequency: 24MHz; ComponentLink1URL: http://www.txccrystal.com/images/pdf/7a.pdf; ComponentLink1Description: http://www.txccrystal.com/images/pdf/7a.pdf; Component Kind: Standard; Category: Crystals and Oscillators; Library Name: Orient.SchLib
X2 = CRYS_32.768kHz: CRYSTAL 32.768KHZ 12.5PF SMD; Manufacturer Part Number: CM200C-32.768KAZF-UT; Manufacturer: Citizen Finetech Miyota; Operating Mode: Fundamental; Frequency Tolerance: ±30ppm; ESR (Equivalent Series Resistance): 50 kOhm; Type: kHz Crystal (Tuning Fork); Supplier Part Number 1: 300-8738-6-ND; Supplier 1: Digi-Key; Stock 1: 0; Size / Dimension: 0.311" L x 0.146" W (7.90mm x 3.70mm); RoHS: RoHS Compliant; Ratings: -; Pricing 1: 1=0.75, 10=0.65, 50=0.57, 100=0.45, 500=0.38, 1000=0.3 (USD); Packaging: Digi-Reel®; Package / Case: 4-SOJ, 5.50mm pitch; Operating Temperature: -40°C ~ 85°C; Mounting Type: Surface Mount; Load Capacitance: 12.5pF; Height: 0.098" (2.50mm); Frequency Stability: -; Frequency: 32.768kHz; ComponentLink1URL: http://cfm.citizen.co.jp/english/product/pdf/CM200C_250C.pdf; ComponentLink1Description: http://cfm.citizen.co.jp/english/product/pdf/CM200C_250C.pdf; Component Kind: Standard; Category: Crystals and Oscillators; Library Name: Orient.SchLib
